# Altium schematic sheet Irrigation_System.SchDoc(Irrigation_System)
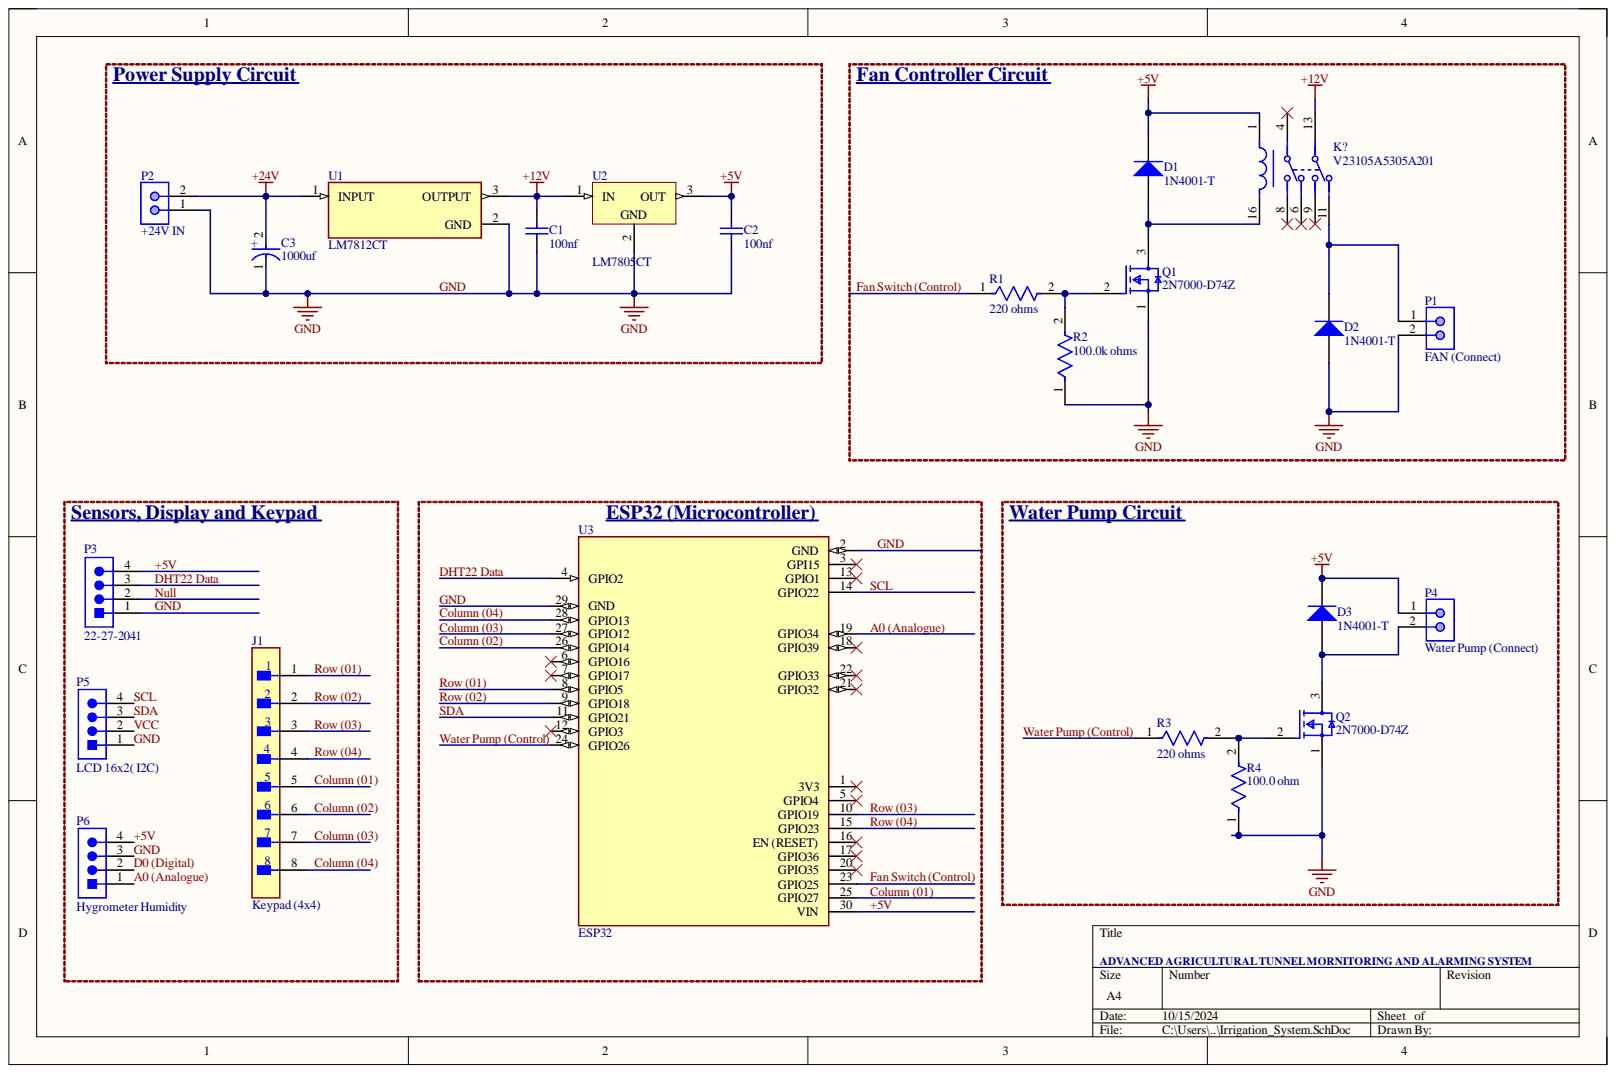
[diagram p0: Irrigation_System.SchDoc]

## Parts
C1  100nf  [CAPR51-254-508X318X736]
C2  100nf  [CAPR51-254-508X318X736]
C3  1000uf  [FP-RAD-TH-D_16_0_5-L_25_2-MFG]
D1  1N4001-T  [DIOD-DO-41-P-2_V]
D2  1N4001-T  [DIOD-DO-41-P-2_V]
D3  1N4001-T  [DIOD-DO-41-P-2_V]
J1  Keypad (4x4)  [FP-22-29-2081-MFG]
K?  V23105A5305A201  [FP-V23105A5305A201-MFG]
P1  FAN (Connect)  [MOLX-22-27-2021_V]
P2  +24V IN  [MOLX-22-27-2021_V]
P3  22-27-2041  [MOLX-22-27-2041_V]
P4  Water Pump (Connect)  [MOLX-22-27-2021_V]
P5  LCD 16x2( I2C)  [MOLX-22-27-2041_V]
P6  Hygrometer Humidity  [MOLX-22-27-2041_V]
Q1  2N7000-D74Z  [FP-135AR-MFG]
Q2  2N7000-D74Z  [FP-135AR-MFG]
R1  220 ohms  [FP-MRS25-MFG]
R2  100.0k ohms  [FP-MRS25-MFG]
R3  220 ohms  [FP-MRS25-MFG]
R4  100.0 ohm  [FP-MRS25-MFG]
U1  LM7812CT  [FP-TO220B03-MFG]
U2  LM7805CT  [FAIR-TO-220-3]
U3  ESP32  [XCVR_ESP32-C3-DEVKITC-02]

## Nets
+12V: C1.2 K?.13 U1.3 U2.1
+24V: C3.2 P2.2 U1.1
+5V: C2.2 D1.2 D3.2 K?.1 P3.4 P4.1 P6.4 U2.3 U3.30(VIN)
A0 (Analogue): P6.1 U3.19(GPIO34)
Column (01): J1.5 U3.25(GPIO27)
Column (02): J1.6 U3.26(GPIO14)
Column (03): J1.7 U3.27(GPIO12)
Column (04): J1.8 U3.28(GPIO13)
D0 (Digital): P6.2
DHT22 Data: P3.3 U3.4(GPIO2)
Fan Switch (Control): R1.1 U3.23(GPIO25)
GND: C1.1 C2.1 C3.1 D2.1 P1.2 P2.1 P3.1 P5.1 P6.3 Q1.1 Q2.1 R2.1 R4.1 U1.2 U2.2 U3.2(GND) U3.29(GND)
Null: P3.2
Row (01): J1.1 U3.8(GPIO5)
Row (02): J1.2 U3.9(GPIO18)
Row (03): J1.3 U3.10(GPIO19)
Row (04): J1.4 U3.15(GPIO23)
SCL: P5.4 U3.14(GPIO22)
SDA: P5.3 U3.11(GPIO21)
VCC: P5.2
Water Pump (Control): R3.1 U3.24(GPIO26)
NetD1_1: D1.1 K?.16 Q1.3
NetD2_2: D2.2 K?.11 P1.1
NetD3_1: D3.1 P4.2 Q2.3
NetQ1_2: Q1.2 R1.2 R2.2
NetQ2_2: Q2.2 R3.2 R4.2

Not connected: K? 4 6 8 9; U3 1(3V3) 3(GPI15) 5(GPIO4) 6(GPIO16) 7(GPIO17) 12(GPIO3) 13(GPIO1) 16(EN_(RESET)) 17(GPIO36) 18(GPIO39) 20(GPIO35) 21(GPIO32) 22(GPIO33)

## Library data of the parts
C1 C2 = CMP-1664-00004-1: Radial Leaded Multilayer Ceramic Capacitor, 0.1 uF, +/- 10%, 50 V, -55 to 125 degC, 2-Pin THD, RoHS, Tape and Reel; Case\Package: SOT-23-5; Dropout Voltage: 260 mV; Max Input Voltage: 8 V; Max Power Dissipation: 250 mW; Output Current: 150 mA; Output Voltage: 5 V; Outputs: 1; Component Kind: Standard; ComponentLink1Description: Manufacturer URL; ComponentLink1URL: http://www.kemet.com/; ComponentLink2Description: Datasheet; ComponentLink2URL: http://www.kemet.com/docfinder?Partnumber=C320C104K5R5TA; DatasheetVersion: Dec-15; Height: 1.3 mm; Length: 3 mm; Library Name: Altium Content Vault; Max Operating Temperature: 85 degC; Min Operating Temperature: -40 degC; Mount: Surface Mount; Package Quantity: 3000; PackageDescription: 2-Pin Through Hole Device, Body 5.08 x 3.18 mm, Pitch 2.54 mm; PackageReference: CAPR51-254-508X318X736; Packaging: Tape and Reel; Pins: 5; Radiation Hardening: No; RoHSCompliant: true; Width: 1.8 mm
C3 = CMP-05427-000487-1: Aluminum Electrolytic Capacitors (Radial Lead Type) 1000uF ±20% 50V; Capacitance: 1mF; ESR (Equivalent Series Resistance): 16mR; Lead Diameter: 0.8mm; Life (Hours): 10000Hour; Polarity: Polar; Ripple Current: 3.32A; Case/Package: Radial; Component Kind: Standard; Contact Plating: Tin; Diameter: 16mm; Features: General Purpose; Height: 25mm; Height - Seated (Max): 0.984inch; Impedance: 16mR; Lead Free: Lead Free; Lead Pitch: 7.5mm; Length: 25mm; Library Name: Altium Content Vault; Max Operating Temperature: 105°C; Min Operating Temperature: -40°C; Mount: Through Hole; Number of Pins: 2; Package Quantity: 250; Packaging: Tape and Reel; Radiation Hardening: No; REACH SVHC: No SVHC; RoHS Compliant: Yes; Series: FR; Termination: Through Hole; Tolerance: 20%; Voltage Rating: 50V; Voltage Rating (DC): 50V
D1-D3 = CMP-0639-00006-2: 1.0 A Rectifier, 15 pF, 50 V, -65 to 150 degC, 2-Pin DO-41 Plastic, RoHS, Tape and Reel; Manufacturer: Diodes Inc; CT (pF): 15; Max. Average Rectified Current IO (A): 1; Max. IFSM (A): 30; Max. IR (uA): 5; VRRM (V): 50; Component Kind: Standard; ComponentLink1Description: Manufacturer URL; ComponentLink1URL: http://diodes.com/; ComponentLink2Description: Datasheet; ComponentLink2URL: http://diodes.com/datasheets/ds28002.pdf; DatasheetVersion: Rev. 8-2; Library Name: Altium Content Vault; Mounting Technology: Through Hole; Operating Temperature Range (degC): -65 to 150; PackageDescription: 2-Pin Axial Lead, Body 4.635 x 2.36 mm, Lead Spread 25.4 mm; PackageReference: DO-41-P-2; Packing: Tape and Reel; PartNumber: 1N4001-T; RoHS: TRUE; VF (V): 1
J1 = CMP-00257-00277737-1: 22-29-2081 KK® 254 Wire-to-Board Header, Vertical, with Friction Lock, 8 Circuits, Gold (Au) Plating; NexarPartId: 277737; Contact Resistance: 10mΩ; Current Rating: 4A; Gender: Male; Glow Wire Compliant: Non-compliant; Insulation Resistance: 50GΩ; Number of Circuits: 8; Pitch: 2.54mm; Voltage Rating: 250V; Color: White; Component Kind: Standard; Contact Material: Brass; Contact Plating: Gold; Flammability Rating: UL94 V-0; Halogen Free: Low Halogen; Height: 11.42mm; Housing Material: Nylon; Lead Free: Lead Free; Lead Pitch: 2.54mm; Library Name: Altium Content Vault; Max Operating Temperature: 75°C; Min Operating Temperature: -40°C; Mount: Through Hole; Number of Contacts: 8; Number of Pins: 8; Number of Positions: 8; Number of Rows: 1; Orientation: Vertical; Packaging: Bulk; Radiation Hardening: No; REACH SVHC: Unknown; RoHS: Compliant; Schedule B: 8536694040; Termination: Through Hole; Voltage Rating (DC): 250V
K? = CMP-03395-000159-1: RELAY TELECOM DPDT 3A 24V; Manufacturer: TE Connectivity; Coil Power: 200mW; Coil Resistance: 2880Ω; Coil Type: Non-Latching; Number Of Contacts: 2; Standoff Height: 0.2mm; Ambient Temperature Range High: +85°C; Ambient Temperature Range Low: -40°C; Automotive: No; Category: Relay; Coil Voltage: 24V; Component Kind: Standard; ComponentLink2Description: Datasheet; ComponentLink2URL: https://www.te.com/commerce/DocumentDelivery/DDEController?Action=showdoc&DocId=Specification+Or+Standard%7F108-98007%7F1017%7Fpdf%7FEnglish%7FENG_SS_108-98007_1017.pdf%7F9-1393792-9; Current Rating: 3A; Device Class L1: Electromechanical; Device Class L2: Relays; Device Class L3: Power Relays; Digikey Description: RELAY TELECOM DPDT 3A 24V; Height: 10.9mm; Lead Free: Yes; Library Name: Altium Content Vault; Manufacturer Part Number: V23105A5305A201; Octopart: https://octopart.com/9-1393792-9-te+connectivity-41176539; Package: V23105A5305A201; RoHS: TRUE; Switching Current: 3A; Temperature: -40°C to +85°C; Temperature Range High: +85°C; Temperature Range Low: -40°C; Throw Configuration: DPDT
P1 P2 P4 = CMP-2000-05537-1: Male Header, Pitch 2.54 mm, 1 x 2 Position, Height 11.7 mm, Tail Length 3.56 mm, 0 to 75 degC, RoHS, Bulk; Manufacturer: Molex; PartNumber: 0022272021; Current - Max: 4A; No. of Positions: 2; No. of Rows: 1; Package Version: Rev. BC, 02/2012; Pitch: 2.54mm; Voltage - Max: 250V; Component Kind: Standard; Contact material: Tinplatedbrass; Current rating: 4A; Datasheet URL: http://www.molex.com/pdm_docs/sd/022272021_sd.pdf; Datasheet Version: Rev. F, 06/2009; Housing material: Nylon(UL94V-0); Library Name: Altium Content Vault; Manufacturer URL: http://www.molex.com/; Mounting Technology: ThroughHole; Operating temperature: 0°Cto+75°C; Orientation: Vertical; Package Description: 2-Leads Header, Body 5.08 x 5.8 mm, Pitch 2.54 mm; Package Reference: 22-27-2021; Voltage rating: 250V
P3 P5 P6 = CMP-2000-05243-1: Male Header, Pitch 2.54 mm, 1 x 4 Position, Height 11.7 mm, Tail Length 3.56 mm, RoHS, Bulk; Manufacturer: Molex; Current rating: 4A; Voltage rating: 250V; Component Kind: Standard; Contact material: Tinplatedbrass; Datasheet URL: http://www.molex.com/pdm_docs/sd/022272041_sd.pdf; Datasheet Version: Rev. F, 06/2009; Housing material: Nylon(UL94V-0); Library Name: Altium Content Vault; Manufacturer URL: http://www.molex.com/; Operating temperature: 0degCto+75degC
Q1 Q2 = CMP-00278-84793556-1: 2N7000-D74Z 2N7000 Series 60V 5 Ohms N-Ch Enhancement Mode Field Effect Transistor - TO-92; Manufacturer Lifecycle Status: ACTIVE; Continuous Drain Current (ID): 200mA; Drain to Source Resistance: 1.2Ω; Gate to Source Voltage (Vgs): 20V; Max Junction Temperature (Tj): 150°C; Number of Channels: 1; Power Dissipation: 400mW; Component Kind: Standard; Drain to Source Breakdown Voltage: 60V; Drain to Source Voltage (Vdss): 60V; Height: 8.77mm; Library Name: Altium Content Vault; Lifecycle Status: Production; Max Operating Temperature: 150°C; Min Operating Temperature: -55°C; NexarPartId: 84793556; RoHS: Compliant; Schedule B: 8541210080
R1-R4 = CMP-00030-42632392-1: MRS25000C1002FCT00 Resistor, 10 kOhm, ± 1%, 600 mW, Axial Leaded, Metal Film (Thin Film), Professional; Lead Length: 26mm; Max Power Dissipation: 600mW; Resistance: 10kΩ; Temperature Coefficient: 50ppm/°C; Voltage Rating: 350V; Case/Package: Conformal; Component Kind: Standard; Composition: Metal Film, Thin Film; Contact Plating: Tin; Diameter: 2.5mm; Lead Free: Lead Free; Length: 6.5mm; Library Name: Altium Content Vault; Max Operating Temperature: 155°C; Military Standard: Not; Min Operating Temperature: -55°C; Mount: Through Hole; NexarPartId: 42632392; Number of Pins: 2; Number of Terminations: 2; Power Rating: 600mW; Radiation Hardening: No; REACH SVHC: Unknown; RoHS: Compliant; Schedule B: 8533210090, 8533210090; Termination: Axial; Tolerance: 1%; Voltage Rating (DC): 350V; Width: 2.5mm
U1 = CMP-07128-000015-1: IC REG LINEAR 12V 1A TO220-3; Manufacturer: ON Semiconductor; Obsolete Part: true; Accuracy Percentage: 4%; Dropout Voltage: 2V; Max Supply Voltage: 35V; Nominal Supply Current: 5.1mA; Number Of Outputs: 1; Output Type: Fixed; Standoff Height: 2.7mm; Automotive: No; Category: IC; Component Kind: Standard; ComponentLink2Description: Datasheet; ComponentLink2URL: https://www.mouser.in/datasheet/2/308/LM7812-1125088.pdf; Device Class L1: Integrated Circuits (ICs); Device Class L2: Power Management ICs; Device Class L3: Voltage Regulators - Linear; Digikey Description: IC REG LINEAR 12V 1A TO220-3; Height: 20.4mm; Ipc Land Pattern Name: TO-220; Lead Free: Yes; Library Name: Altium Content Vault; Manufacturer Part Number: LM7812CT; Octopart: https://octopart.com/lm7812ct-on+semiconductor-14383031; Output Current: 1A; Output Voltage: 12V; Package: TO220B03; RoHS: TRUE; Temperature: -40°C to +125°C; Temperature Range High: +125°C; Temperature Range Low: -40°C
U2 = CMP-2000-04938-1: Positive Voltage Regulator, 5 V, 1 A, -40 to 125 degC, 3-Pin TO-220, RoHS, Tube; Manufacturer: FairchildSemiconductor; Accuracy: ±4%; Line Regulation: 100mV; Maximum Input Voltage: 35V; Maximum Output Current: 2.2(Typ)A; Maximum Quiescent Current: 8mA; Number of Outputs: 1; Output Type: Fixed; Output Voltage: 5V; Rad Hard: No; Typical Dropout Voltage @ Current: 2@1AV; Category: LinearRegulator; Component Kind: Standard; Datasheet URL: https://datasheet.ciiva.com/26904/lm7805ct-26904988.pdf; Datasheet Version: Aug-12; Library Name: Altium Content Vault; Load Regulation: 100mV; Manufacturer URL: https://www.fairchildsemi.com/; Mounting: ThroughHole; Operating Temperature: -40to125degC; Package: 3TO-220AB; Package Description: 3-Pin Through Hole Device, Body 10 x 4.5 mm, Pitch 2.54 mm; Package Reference: TO-220; Package URL: https://www.fairchildsemi.com/package-drawings/TO/TO220B03.pdf; Packaging: Rail/Tube; Polarity: Positive; Type: Linear
U3 = ESP32-C3-DEVKITC-02: Development Boards & Kits - Wireless (Engineering Samples) ESP32-C3 General-Purpose Development Board, embeds ESP32-C3-WROOM-02, 4 MB Flash, with Pin Header; MF: Espressif Systems; Availability: In Stock; Check_prices: https://www.snapeda.com/parts/ESP32-C3-DevKitC-02/Espressif+Systems/view-part/?ref=eda; Component Kind: Standard; Library Name: ESP32-C3-DEVKITC-02.IntLib; MP: ESP32-C3-DevKitC-02; Package: None; Price: None; Purchase-URL: https://pricing.snapeda.com/search/part/ESP32-C3-DevKitC-02/?ref=eda; SnapEDA_Link: https://www.snapeda.com/parts/ESP32-C3-DevKitC-02/Espressif+Systems/view-part/?ref=snap
